# Altium schematic sheet 555_Timer_Organ_Schematic.SchDoc(555_Timer_Organ_Schematic)
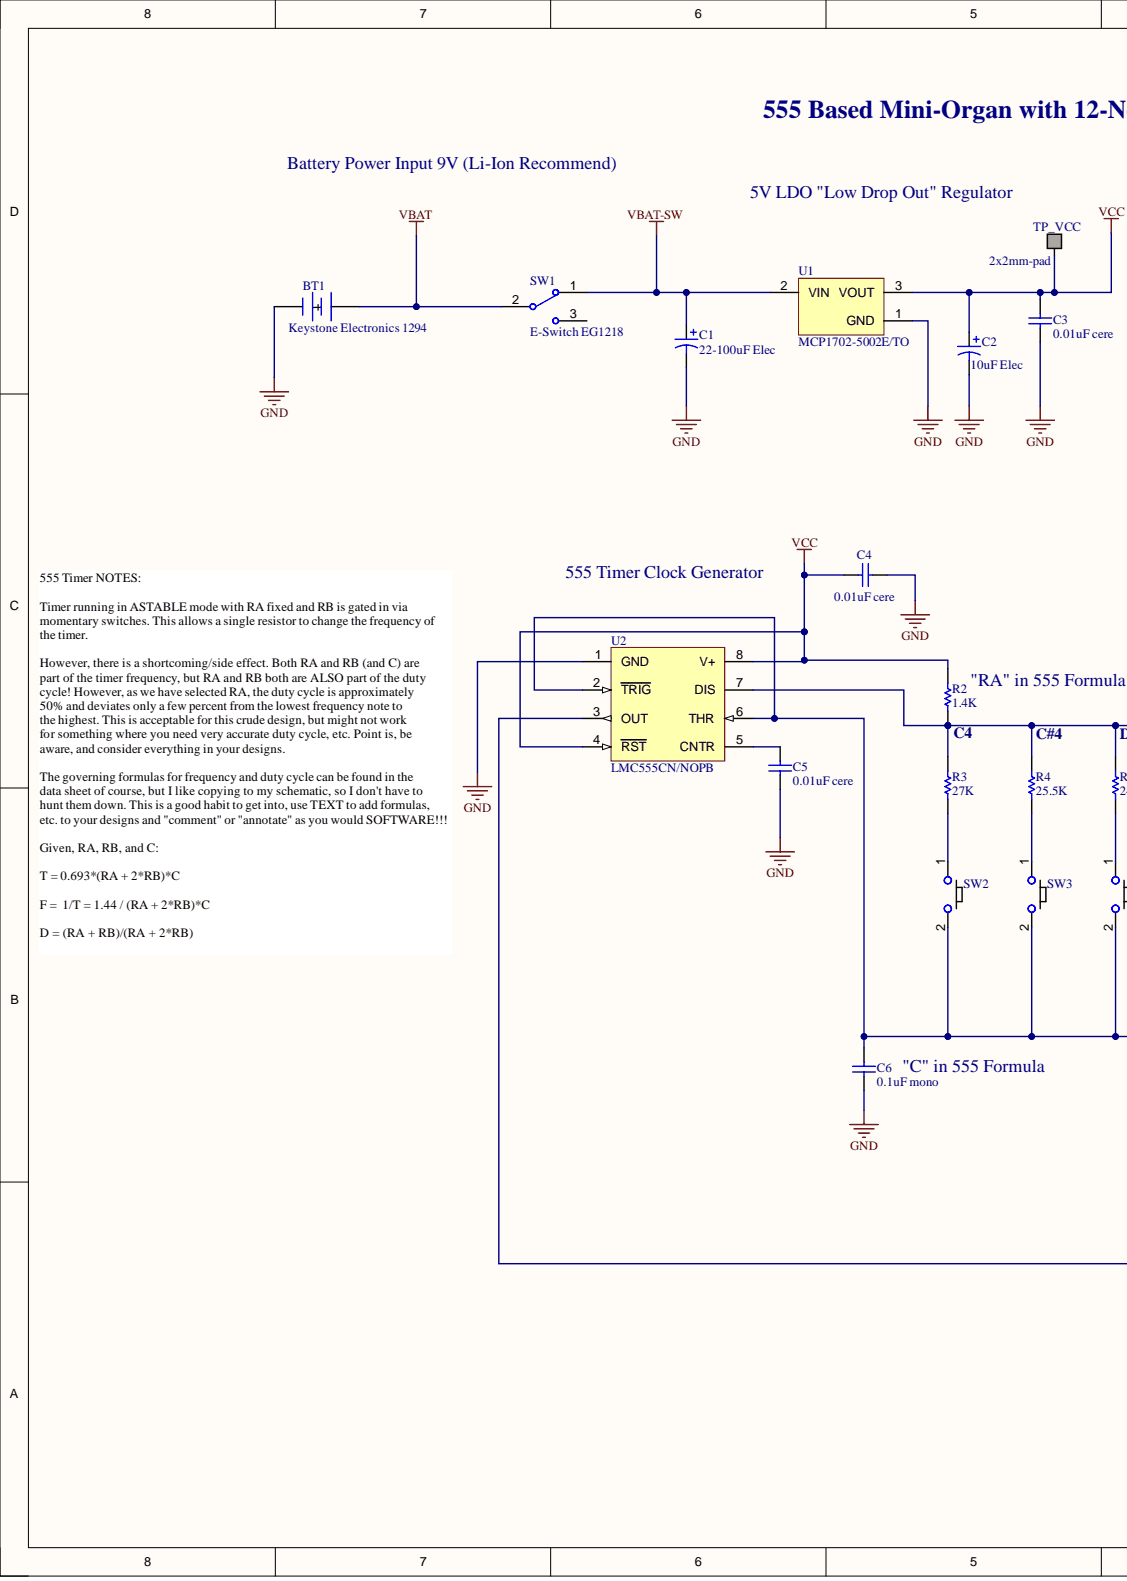
[diagram p0: 555_Timer_Organ_Schematic.SchDoc, left]
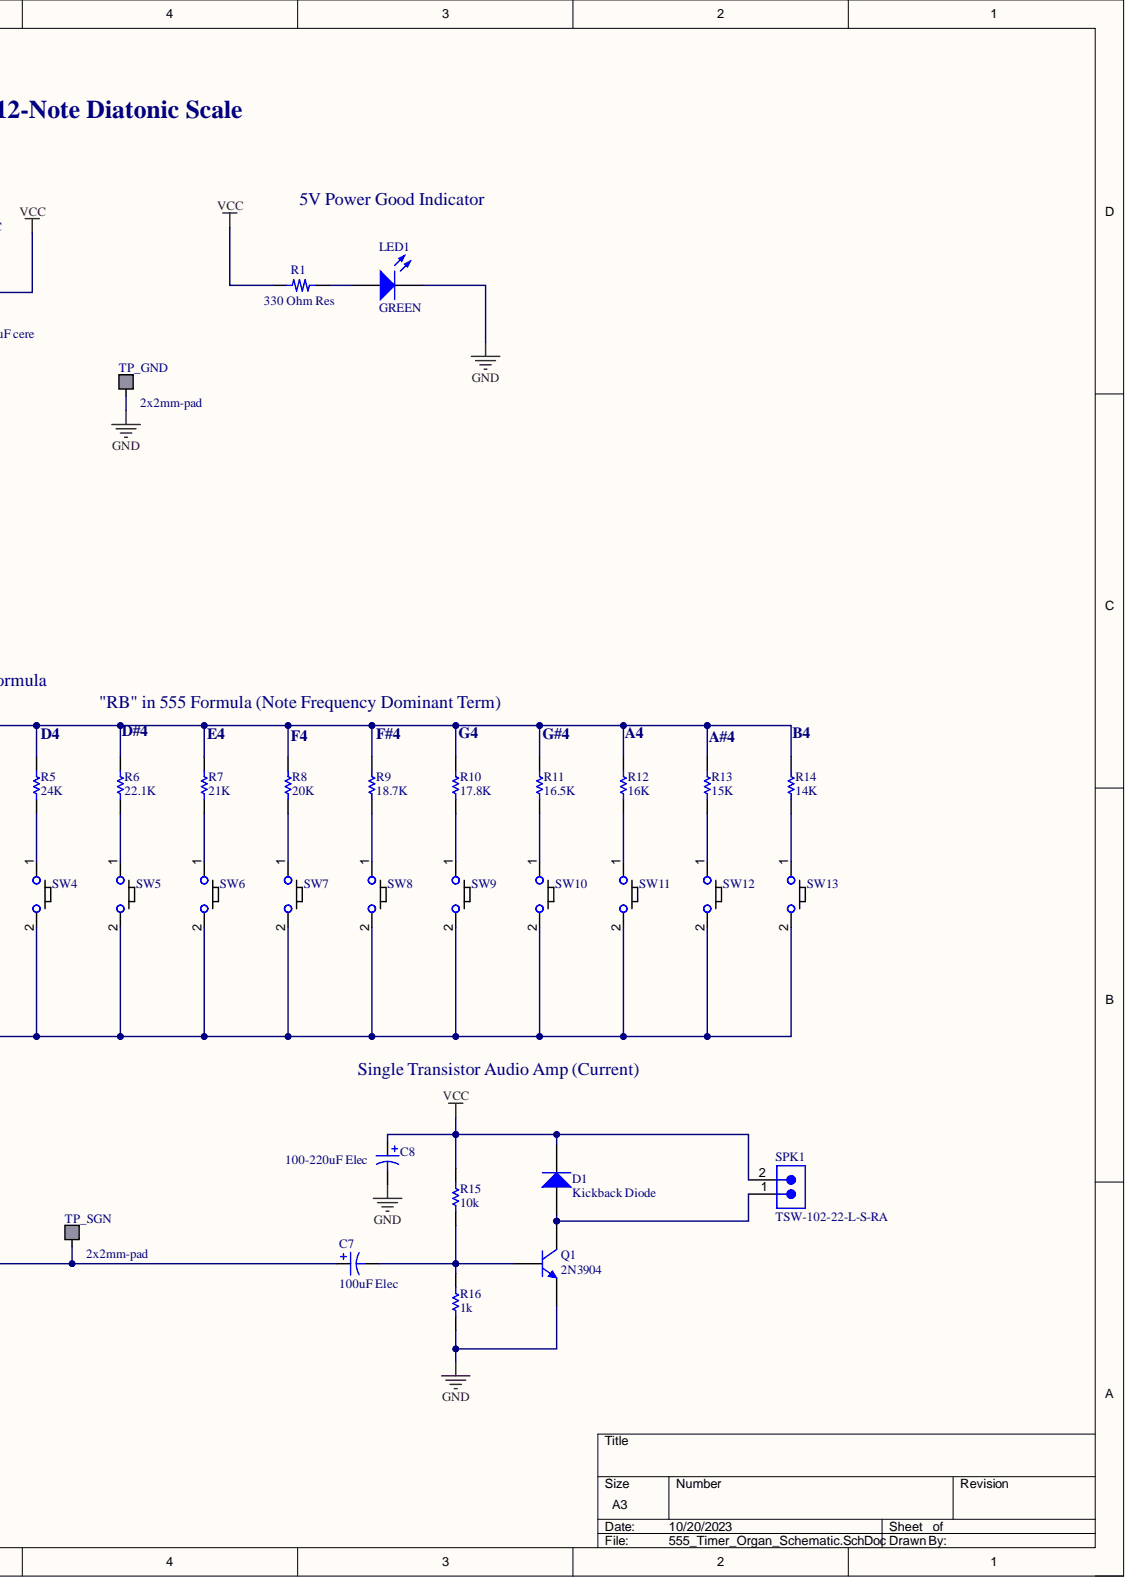
[diagram p1: 555_Timer_Organ_Schematic.SchDoc, right]

## Parts
BT1  Keystone Electronics 1294
C1  22-100uF Elec
C2  10uF Elec
C3  0.01uF cere
C4  0.01uF cere
C5  0.01uF cere
C6  0.1uF mono
C7  100uF Elec
C8  100-220uF Elec
D1  Kickback Diode
LED1  GREEN
Q1  2N3904  [PCB-0sfpb0c87ousukqjmm98-8]
R1  330 Ohm Res
R2  1.4K
R3  27K
R4  25.5K
R5  24K
R6  22.1K
R7  21K
R8  20K
R9  18.7K
R10  17.8K
R11  16.5K
R12  16K
R13  15K
R14  14K
R15  10k
R16  1k
SPK1  TSW-102-22-L-S-RA
SW1  E-Switch EG1218  Manufacturer Part Number: EG1218
SW2  SW2  [PCB-o8s2g06f420t5u4y06lu-2]
SW3  SW3  [PCB-o8s2g06f420t5u4y06lu-2]
SW4  SW4  [PCB-o8s2g06f420t5u4y06lu-2]
SW5  SW5  [PCB-o8s2g06f420t5u4y06lu-2]
SW6  SW6  [PCB-o8s2g06f420t5u4y06lu-2]
SW7  SW7  [PCB-o8s2g06f420t5u4y06lu-2]
SW8  SW8  [PCB-o8s2g06f420t5u4y06lu-2]
SW9  SW9  [PCB-o8s2g06f420t5u4y06lu-2]
SW10  SW10  [PCB-o8s2g06f420t5u4y06lu-2]
SW11  SW11  [PCB-o8s2g06f420t5u4y06lu-2]
SW12  SW12  [PCB-o8s2g06f420t5u4y06lu-2]
SW13  SW13  [PCB-o8s2g06f420t5u4y06lu-2]
TP_GND  2x2mm-pad
TP_SGN  2x2mm-pad
TP_VCC  2x2mm-pad
U1  MCP1702-5002E/TO
U2  LMC555CN/NOPB

## Nets
GND: BT1.2 C1.2 C2.- C3.1 C4.1 C5.1 C6.1 C8.2 LED1.1 Q1.E R16.1 TP_GND.1 U1.1 U2.1(GND)
VBAT: BT1.1 SW1.2
VBAT-SW: C1.1 SW1.1 U1.2
VCC: C2.+ C3.2 C4.2 C8.1 D1.2 R1.1 R2.2 R15.2 SPK1.2 TP_VCC.1 U1.3 U2.4(RST) U2.8(V+)
NetC5_2: C5.2 U2.5(CNTR)
NetC6_2: C6.2 SW2.2 SW3.2 SW4.2 SW5.2 SW6.2 SW7.2 SW8.2 SW9.2 SW10.2 SW11.2 SW12.2 SW13.2 U2.2(TRIG) U2.6(THR)
NetC7_1: C7.1 TP_SGN.1 U2.3(OUT)
NetC7_2: C7.2 Q1.B R15.1 R16.2
NetD1_1: D1.1 Q1.C SPK1.1
NetLED1_2: LED1.2 R1.2
NetR2_1: R2.1 R3.2 R4.2 R5.2 R6.2 R7.2 R8.2 R9.2 R10.2 R11.2 R12.2 R13.2 R14.2 U2.7(DIS)
NetR3_1: R3.1 SW2.1
NetR4_1: R4.1 SW3.1
NetR5_1: R5.1 SW4.1
NetR6_1: R6.1 SW5.1
NetR7_1: R7.1 SW6.1
NetR8_1: R8.1 SW7.1
NetR9_1: R9.1 SW8.1
NetR10_1: R10.1 SW9.1
NetR11_1: R11.1 SW10.1
NetR12_1: R12.1 SW11.1
NetR13_1: R13.1 SW12.1
NetR14_1: R14.1 SW13.1

Not connected: SW1 3

## Library data of the parts
BT1 = CMP-3798-4: HOLDER BATT 9V UNIV PLASTIC PC; Manufacturer Part Number: 1294; Lead-Free Status: Lead Free; Number of Contacts: 2; Component Kind: Standard; Contact Material: Steel; Contact Plating: Nickel; Library Name: My Personal Space; Material: Plastic; Octopart Url: https://octopart.com/1294-keystone-3743; OctopartID: fd44344ed6bd2283; Packaging: Bulk; REACH SVHC Compliance: No SVHC; RoHS: Compliant
C1 C7 = CMP-f0e23b7c3dc72f25-3: 150uF 6.3mm diameter 2.5mm lead spacing; Manufacturer Part Number: ECE-A1EKA470I; Capacitance: 47.0 µF; Capacitance Tolerance: ±20%; Lead-Free Status: Lead Free; Voltage Rating (DC): 25.0 V; Component Kind: Standard; Library Name: My Personal Space; Lifecycle Status: Not Listed by Manufacturer; Octopart Url: https://octopart.com/ece-a1eka470i-panasonic-43313219; OctopartID: f0e23b7c3dc72f25; Operating Temperature: -40.0 °C (min); Packaging: Cut Tape (CT); REACH SVHC Compliance: No SVHC; RoHS: Compliant; Size-Diameter: 6.30 mm; Size-Height: 7.00 mm
C2 = CMP-b868704b0d3c67af-1: Electrolytic capacitor Radial lead 2.5 mm 10 µF 16 V 20 % (Ø) 4 mm Panasonic EEA-GA1C100H 1 pc(s); Capacitance Tolerance: ±20%; Voltage Rating (DC): 16.0 V; Capacitance: 10 uF; Component Kind: Standard; Digikey PN: P15787CT-ND; Digikey URL: http://www.digikey.com/product-detail/en/panasonic-electronic-components/EEA-GA1C100H/P15787CT-ND/3831154; Dimension: DxLxF = 4x7x2.5mm; Library Name: FavoritesLibrary; Lifecycle Status: Not Listed by Manufacturer; Manufacturer Part Number: EEA-GA1C100H; Octopart URL: http://octopart.com/eea-ga1c100h-panasonic-19501106; OctopartID: b868704b0d3c67af; RoHS: Compliant; Size-Diameter: 4.00 mm; Size-Height: 7.00 mm
C3-C5 = CMP-fcb037e760c32377-3: K Series 10000 pF 50 V ±10% X7R LS=2.5 mm Mono-Kap Ceramic Capacitor; Manufacturer Part Number: K103K15X7RF53L2; Component Kind: Standard; Library Name: My Personal Space
C6 = CMP-1272d9258f2acdb5-7: K 100nF Ceramic Multilayer Capacitor, 50 V dc, +125degC, X7R Dielectric, +/-10%; Component Kind: Standard; Library Name: My Personal Space
C8 = CMP-23faeaf33612fc3d-3: Cap Aluminum Lytic 100uF 35V 20% (6.3 X 11.2mm) Radial 2.5mm 210mA 2000h 85C Bulk; Manufacturer Part Number: ECA-1VM101; Capacitance: 100 µF; Dielectric Material: Aluminum Electrolytic; Lead-Free Status: Lead Free; Voltage Rating (DC): 35.0 V, 63.0 V; Capacitance Tolerance: ±20%; Component Kind: Standard; Library Name: My Personal Space; Lifecycle Status: Not Listed by Manufacturer; Mounting Style: Through Hole; Number of Pins: 2; Octopart URL: http://octopart.com/eca-1vm101-panasonic-209038; OctopartID: 23faeaf33612fc3d; Packaging: Bulk; REACH SVHC Compliance: No SVHC; RoHS: Compliant; Size-Diameter: 6.30 mm; Size-Height: 11.2 mm; Size-Length: 11.2 mm
D1 = CMP-816873-16: DIODE RECTIFIER Do-41 1N4001. 1N4002. 1N4003. 1N4004. 1N4005. 1N4006. 1N4007,Do-35 1N4148,DO-201,DO-204(DO-15); Manufacturer Part Number: 1N4001G; Voltage MAX Rating (DC) (1N4007): 1000V; Component Kind: Standard; Library Name: My Personal Space; OctopartID: e02d2927e6e83fea
LED1 = CMP-92bb5b2eff764d7c-3: LED Uni-Color Green 2-Pin T-1 3/4 Bulk; Manufacturer: Cree; Manufacturer Part Number: C503B-GCN-CY0C0791; Forward Voltage: 3.20 V; Luminous Intensity: 12.5 cd; Viewing Angle: 30 °; Wavelength: 527 nm; Color: Green; Component Kind: Standard; Library Name: FavoritesLibrary; Mounting Style: Through Hole; Number of Pins: 2; Octopart URL: http://octopart.com/c503b-gcn-cy0c0791-cree-10438827; OctopartID: 92bb5b2eff764d7c; RoHS: Compliant
Q1 = CMP-6928a0272c0fb6ef-21: Transistor General Purpose BJT NPN 40 Volt 0.2 Amp 3-Pin TO-92 Tape And Reel; Manufacturer Part Number: 2N3904TF; Current Rating: 200 mA; Lead-Free Status: Lead Free; Polarity: NPN, N-Channel; Power Dissipation: 625 mW (max); Voltage Rating (DC): 40.0 V; Case/Package: TO-92; Component Kind: Standard; Library Name: My Personal Space; Lifecycle Status: Active; Mounting Style: Through Hole; Number of Pins: 3; Octopart URL: https://octopart.com/2n3904tf-on+semiconductor-84408862; OctopartID: 3e48f245e130e18f; Operating Temperature: 150 °C (max); Packaging: Tape; REACH SVHC Compliance: No SVHC; RoHS: Compliant
R1 = CMP-2034312-6: RES 330 OHM 1/4W 5% CARBON FILM; Manufacturer Part Number: CF14JT330R; Lead-Free Status: Lead Free; Component Kind: Standard; Library Name: My Personal Space; Octopart Url: https://octopart.com/cf14jt330r-stackpole+electronics-19205470; OctopartID: 8faa4785a792506d; Packaging: Tape & Reel (TR); Resistance Tolerance: ±5%; RoHS: Compliant
R2-R16 = CMP-db3e1311f37e04f9-1: Res Carbon Film 1K Ohm 5% 1/4W -400ppm/°C to 0ppm/°C Conformal AXL Thru-Hole T/R; Manufacturer Part Number: CF14JT1K00; Lead-Free Status: Lead Free; Component Kind: Standard; Library Name: FavoritesLibrary; Mounting Style: Axial, Through Hole; Octopart URL: http://octopart.com/cf14jt1k00-stackpole+electronics-19205414; OctopartID: db3e1311f37e04f9; Packaging: Tape & Reel (TR); Resistance Tolerance: ±5%; RoHS: Compliant
SPK1 = CMP-668697-2: 0.025" SQ Post Header, Through-hole, Right Angle, -55 to 125 degC, 2.54 mm Pitch, 2-Pin, Male, RoHS; Number of Contacts: 2; Pin Pitch: 0.00254 m; Component Kind: Standard; Contact Material: Phosphor Bronze; Contact Plating: Gold; Gender: Male; Library Name: My Personal Space; Manufacturer Part Number: TSW-102-22-L-S-RA; Mounting Style: Through Hole; Number of Rows: 1; Octopart Url: https://octopart.com/tsw-102-22-l-s-ra-samtec-11758137; OctopartID: 0b86c23f44d9d441; REACH SVHC Compliance: No SVHC; RoHS: Compliant
SW1 = CMP-9a5a5340a2f5459d-9: Slide Switch, 500 V, -20 to 70 degC, 3-Pin THD, RoHS; Actuator Type: Standard; Contacts Type: SPDT; Current Rating: 200 mA; Lead-Free Status: Lead Free; Number of Positions: 2; Component Kind: Standard; Contact Material: Copper Alloy; Contact Plating: Silver; DatasheetVersion: Oct-15; Library Name: My Personal Space; Mounting Style: PCB, Through Hole; Octopart Url: http://octopart.com/eg1218-e-switch-1056201; OctopartID: 9a5a5340a2f5459d; Orientation: Vertical; PackageDescription: 3-Pin Through Hole Device, Body 11.6 x 4 mm, Pitch 2.5 mm; PackageReference: EG1218; Packaging: Bulk; RoHS: Compliant; Seller Landing  Url: https://octopart-clicks.com/click/track?country=US&ak=8157f166&sig=0e9adab&sid=459&ppid=1056201&vpid=1179261&ct=offers; Size-Height: 5.40 mm; Size-Length: 2.03 mm; Size-Width: 4.00 mm
SW2-SW13 = CMP-441d8f7279709bda-16: Switch Tactile OFF (ON) SPST Round Button PC Pins 0.05A 12VDC 1.57N Thru-Hole T/R; Manufacturer Part Number: FSM4JRT; Contact Current Rating: 50.0 mA; ELV Compliant: Compliant; Lead-Free Status: Lead Free; Component Kind: Standard; Contact Material: Copper Alloy; Contact Plating: Silver; Contacts Type: SPST; Library Name: My Personal Space; Lifecycle Status: Active; Mounting Style: Through Hole; Octopart URL: https://octopart.com/fsm4jrt-te+connectivity+%2F+alcoswitch-40415111; OctopartID: 0645cd278ca4d982; Packaging: Tape; REACH SVHC Compliance: No SVHC; RoHS: Compliant
TP_GND TP_SGN TP_VCC = RCT-0C: Raychem; SMT Circuit probe pad, 0805, 0R0 RCT; Component Kind: Standard; Library Name: Octopart library
U1 = MCP1702-5002E/TO: 250 mA Low Quiescent Current LDO Regulator, 3-Pin TO-92, Extended TemperatureBAG; Dropout Voltage: 0.33 V; Lead-Free Status: Lead Free; Number of Outputs: 1; Output Voltage: 5.0 V; Quiescent Current: 2e-06 A; Case/Package: TO-92; Component Kind: Standard; Library Name: Octopart library; Lifecycle Status: Active; Mounting Style: Through Hole; Number of Pins: 3; Octopart Url: https://octopart.com/mcp1702-5002e%2Fto-microchip-535762; OctopartID: b1707872b8c46eb7; Packaging: Bulk; REACH SVHC Compliance: No SVHC; RoHS: Compliant
U2 = LMC555CN/NOPB: CMOS Timer, 8-pin MDIP, Pb-Free; Frequency: 3000000.0 Hz; Lead-Free Status: Lead Free; Quiescent Current: 5e-05 A; Component Kind: Standard; Library Name: Octopart library; Lifecycle Status: Active; Mounting Style: Through Hole; Number of Pins: 8; Octopart Url: https://octopart.com/lmc555cn%2Fnopb-texas+instruments-24815228; OctopartID: 3409fc79b713ebce; Packaging: Tube; RoHS: Compliant
